# Altium schematic sheet Sheet3.SchDoc(Sheet3)
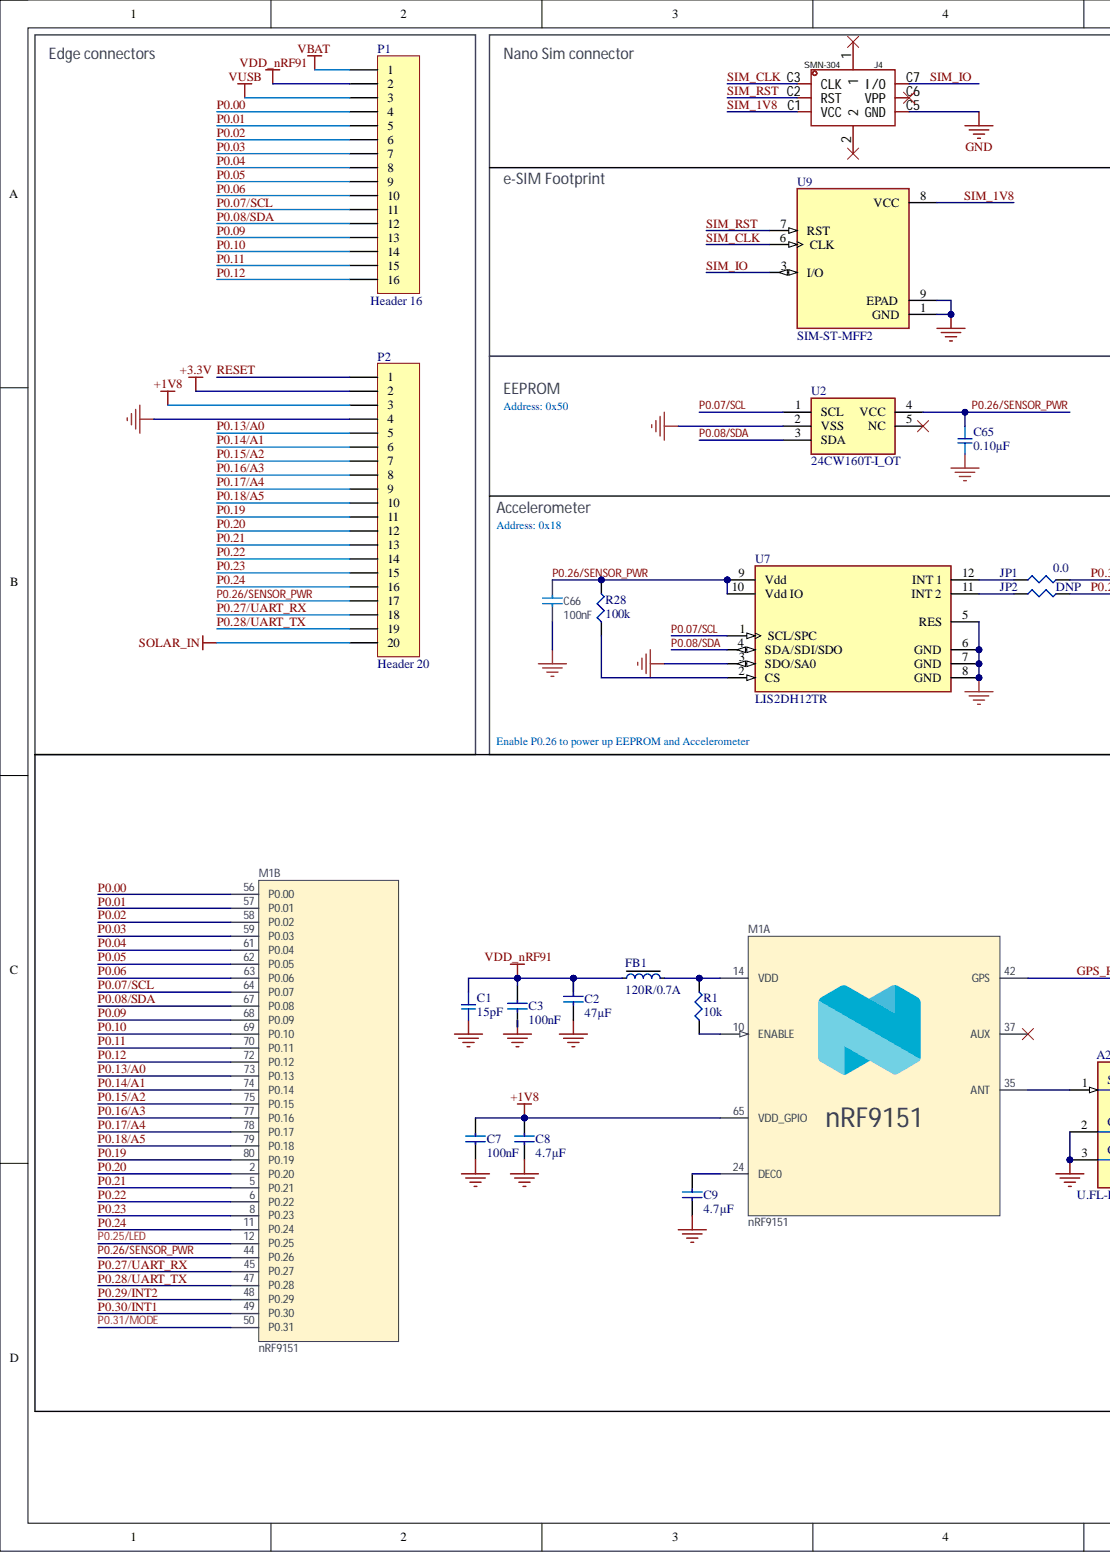
[diagram p0: Sheet3.SchDoc, left]
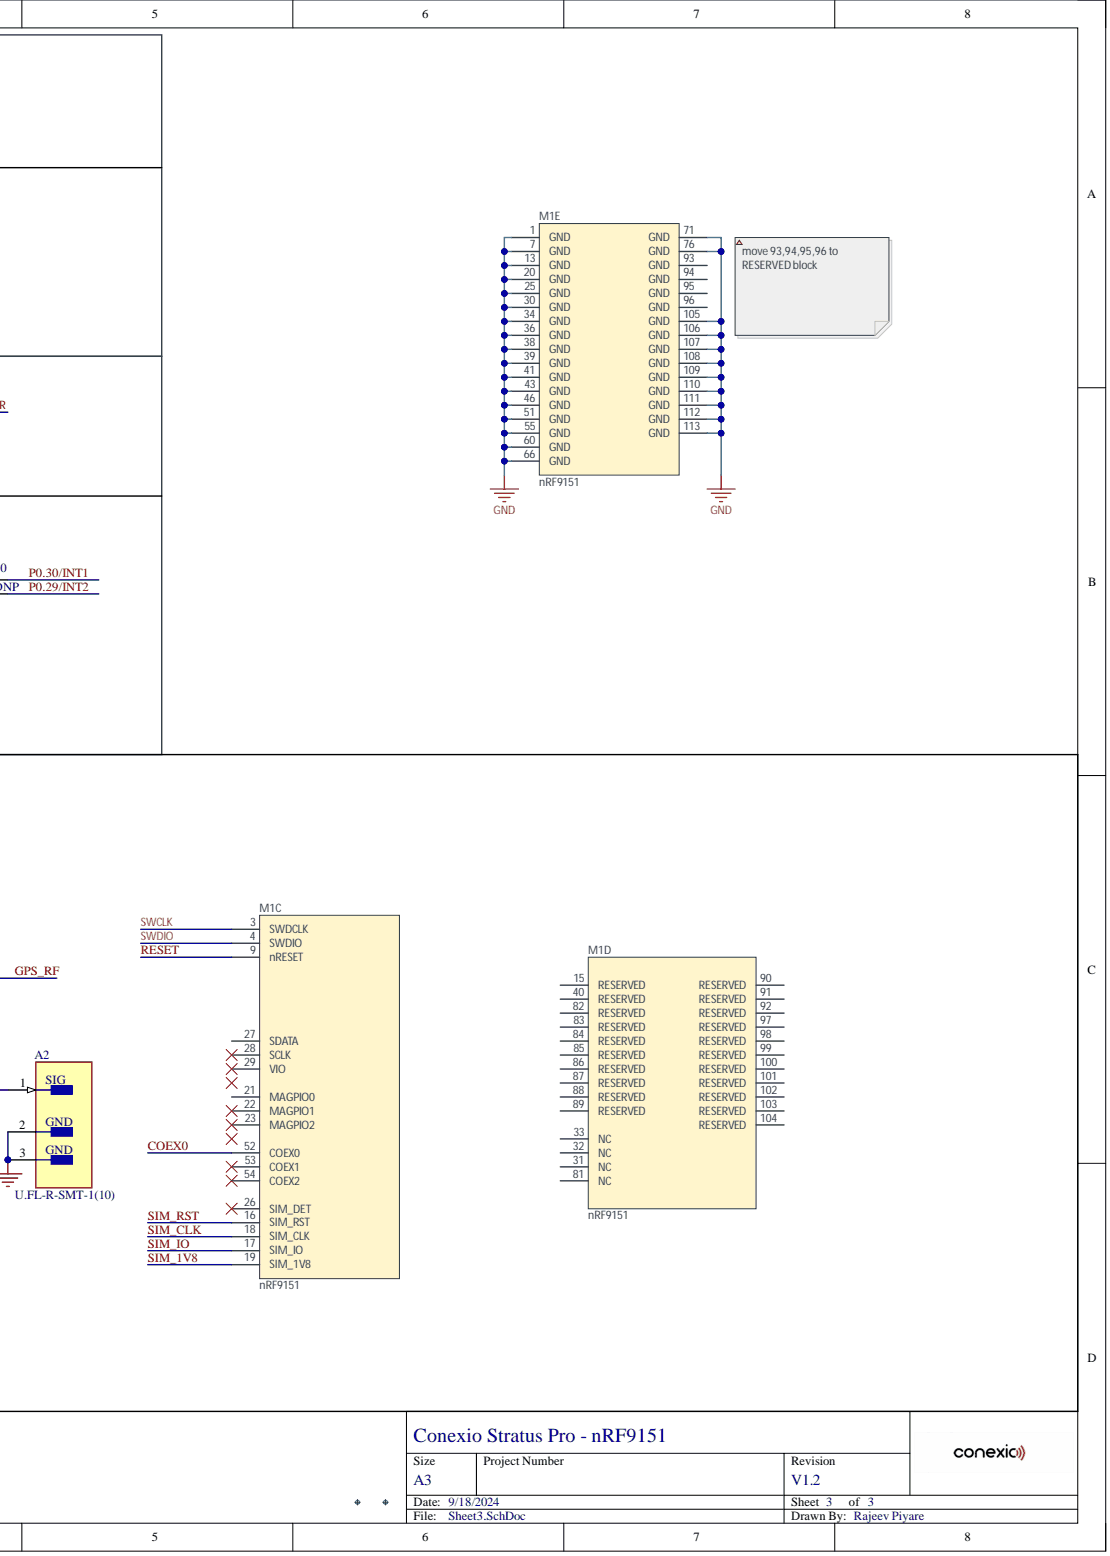
[diagram p1: Sheet3.SchDoc, right]

## Parts
A2  U.FL-R-SMT-1(10)
C1  15pF
C2  47µF
C3  100nF
C7  100nF
C8  4.7µF
C9  4.7µF
C65  0.10µF
C66  100nF
FB1  120R/0.7A
J4  SMN-304
JP1  0.0
JP2  DNP
M1  nRF9151  (parts A,B,C,D,E)
P1  Header 16
P2  Header 20
R1  10k
R28  100k
U2  24CW160T-I_OT
U7  LIS2DH12TR
U9  SIM-ST-MFF2

## Nets
+1V8: C7.1 C8.1 M1.65(VDD_GPIO) P2.3
+3.3V: P2.2
GND: A2.2 A2.3 C1.2 C2.2 C3.2 C7.2 C8.2 C9.2 C65.2 C66.1 J4.C5(GND) M1.1(GND) M1.7(GND) M1.13(GND) M1.20(GND) M1.25(GND) M1.30(GND) M1.34(GND) M1.36(GND) M1.38(GND) M1.39(GND) M1.41(GND) M1.43(GND) M1.46(GND) M1.51(GND) M1.55(GND) M1.60(GND) M1.66(GND) M1.71(GND) M1.76(GND) M1.105(GND) M1.106(GND) M1.107(GND) M1.108(GND) M1.109(GND) M1.110(GND) M1.111(GND) M1.112(GND) M1.113(GND) P2.4 U2.2(VSS) U7.3(SDO/SA0) U7.5(RES) U7.6(GND) U7.7(GND) U7.8(GND) U9.1(GND) U9.9(EPAD)
SOLAR_IN: P2.20
VBAT: P1.1
VDD_nRF91: C1.1 C2.1 C3.1 FB1.1 P1.2
VUSB: P1.3
COEX0: M1.52(COEX0)
GPS_RF: M1.42(GPS)
P0.00: M1.56(P0.00) P1.4
P0.01: M1.57(P0.01) P1.5
P0.02: M1.58(P0.02) P1.6
P0.03: M1.59(P0.03) P1.7
P0.04: M1.61(P0.04) P1.8
P0.05: M1.62(P0.05) P1.9
P0.06: M1.63(P0.06) P1.10
P0.07/SCL: M1.64(P0.07) P1.11 U2.1(SCL) U7.1(SCL/SPC)
P0.08/SDA: M1.67(P0.08) P1.12 U2.3(SDA) U7.4(SDA/SDI/SDO)
P0.09: M1.68(P0.09) P1.13
P0.10: M1.69(P0.10) P1.14
P0.11: M1.70(P0.11) P1.15
P0.12: M1.72(P0.12) P1.16
P0.13/A0: M1.73(P0.13) P2.5
P0.14/A1: M1.74(P0.14) P2.6
P0.15/A2: M1.75(P0.15) P2.7
P0.16/A3: M1.77(P0.16) P2.8
P0.17/A4: M1.78(P0.17) P2.9
P0.18/A5: M1.79(P0.18) P2.10
P0.19: M1.80(P0.19) P2.11
P0.20: M1.2(P0.20) P2.12
P0.21: M1.5(P0.21) P2.13
P0.22: M1.6(P0.22) P2.14
P0.23: M1.8(P0.23) P2.15
P0.24: M1.11(P0.24) P2.16
P0.25/LED: M1.12(P0.25)
P0.26/SENSOR_PWR: C65.1 C66.2 M1.44(P0.26) P2.17 R28.2 U2.4(VCC) U7.9(Vdd) U7.10(Vdd_IO)
P0.27/UART_RX: M1.45(P0.27) P2.18
P0.28/UART_TX: M1.47(P0.28) P2.19
P0.29/INT2: JP2.2 M1.48(P0.29)
P0.30/INT1: JP1.2 M1.49(P0.30)
P0.31/MODE: M1.50(P0.31)
RESET: M1.9(nRESET) P2.1
SIM_1V8: J4.C1(VCC) M1.19(SIM_1V8) U9.8(VCC)
SIM_CLK: J4.C3(CLK) M1.18(SIM_CLK) U9.6(CLK)
SIM_IO: J4.C7(I/O) M1.17(SIM_IO) U9.3(I/O)
SIM_RST: J4.C2(RST) M1.16(SIM_RST) U9.7(RST)
SWCLK: M1.3(SWDCLK)
SWDIO: M1.4(SWDIO)
NetA2_1: A2.1 M1.35(ANT)
NetC9_1: C9.1 M1.24(DEC0)
NetFB1_2: FB1.2 M1.14(VDD) R1.2
NetJP1_1: JP1.1 U7.12(INT_1)
NetJP2_1: JP2.1 U7.11(INT_2)
NetM1_10: M1.10(ENABLE) R1.1
NetR28_1: R28.1 U7.2(CS)

Not connected: J4 1 2 C6(VPP); M1 15(RESERVED) 21(MAGPIO0) 22(MAGPIO1) 23(MAGPIO2) 26(SIM_DET) 27(SDATA) 28(SCLK) 29(VIO) 31(NC) 32(NC) 33(NC) 37(AUX) 40(RESERVED) 53(COEX1) 54(COEX2) 81(NC) 82(RESERVED) 83(RESERVED) 84(RESERVED) 85(RESERVED) 86(RESERVED) 87(RESERVED) 88(RESERVED) 89(RESERVED) 90(RESERVED) 91(RESERVED) 92(RESERVED) 93(GND) 94(GND) 95(GND) 96(GND) 97(RESERVED) 98(RESERVED) 99(RESERVED) 100(RESERVED) 101(RESERVED) 102(RESERVED) 103(RESERVED) 104(RESERVED); U2 5(NC)

## Library data of the parts
A2 = CMP-2000-05152-3: CONN U.FL RCPT STR 50 OHM SMD; Body Material: Bronze; Body Plating: Silver; Connector Type: Coaxial; Dielectric Material: Polymer; Frequency: 6GHz; Gender: Male; Impedance: 50R; Insulation Resistance: 500MR; Component Kind: Standard; Contact Material: Brass; Contact Plating: Gold; Depth: 3.1mm; Fastening Type: Snap; Housing Color: Beige; Lead Free: Lead Free; Length: 3mm; Library Name: Altium Content Vault; Max Frequency: 6GHz; Max Operating Temperature: 90°C; Min Operating Temperature: -40°C; Mount: Surface Mount; Orientation: Straight; Package Quantity: 2500; Packaging: Cut Tape; Radiation Hardening: No; REACH SVHC: No SVHC; Series: U.FL; Termination: Solder; Weight: 0.001764oz; Wire/Cable Type: Coaxial
C1 = GCM1885C1H150JA16D: CAP CER 15PF 50V NP0 0603; Manufacturer: Murata Electronics North America; @ qty: 0; Minimum Quantity: 1; Temperature Coefficient: C0G, NP0; Tolerance: ±5%; Unit Price (USD): 0.1; Applications: Automotive; Component Kind: Standard; Datasheets: http://www.murata.com/~/media/webrenewal/support/library/catalog/products/capacitor/mlcc/c03e.ashx; Digi-Key Part Number: 490-4957-1-ND; Factory Stock: 0; Features: -; Height - Seated (Max): -; Image: //media.digikey.com/Renders/Murata%20Renders/0603%28GCM188%29.jpg; Lead Spacing: -; Lead Style: -; Library Name: capacitor_murata_0603.IntLib; Mounting Type: Surface Mount, MLCC; Operating Temperature: -55°C ~ 125°C; Package / Case: 0603 (1608 Metric); Packaging: Cut Tape (CT); Part Number: GCM1885C1H150JA16D; Part Status: Active; Quantity Available: 99209; Ratings: AEC-Q200; Series: GCM; Size / Dimension: 0.063" L x 0.031" W (1.60mm x 0.80mm); Thickness (Max): 0.035" (0.90mm); Value: 15pF; Voltage: 50V
C2 = C0805-47µ-10V: Capacitor, X5R, ±20%, 10V; Manufacturer: Murata; Manufacturer Part Number: GRM21BR61A476ME15; Part number IPS: 00000004759; Artikkelnr Noca: N.A.; Database Table Name: Parts$; Pad Count: 2; Component Class: Capacitors; Component Kind: Standard; ComponentLink1Description: Datasheet; ComponentLink1URL: https://search.murata.co.jp/Ceramy/image/img/A01X/G101/ENG/GRM21BR61A476ME15-01A.pdf; ComponentLink2Description: Supplier link; ComponentLink2URL: N.A.; Footprint Doc: 0805; HelpURL: https://www.murata.com/en-global/products/productdetail?partno=GRM21BR61A476ME15%23; LatestRevisionDate: 2020-10-23; Library Name: AltiumLib.DbLib; Note: 10V; Part Number: C0805-47µ-10V; PartId: 100200288; Published: 2020-08-14; Supplier 1: Digi-Key; Supplier 2: N.A.; Supplier Part Number 1: 490-9961-1-ND; Supplier Part Number 2: N.A.; Value: 47µF
C3 C7 = C0201-100n: Capacitor, X5R, ±10%; Part number IPS: 00000001248, 00000002505; Artikkelnr Noca: N.A.; Database Table Name: Parts$; Note: 16V; Pad Count: 2; Component Class: Capacitors; Component Kind: Standard; ComponentLink1Description: Datasheet; ComponentLink1URL: N.A.; ComponentLink2Description: Supplier link; ComponentLink2URL: N.A.; Footprint Doc: 0201; HelpURL: N.A.; LatestRevisionDate: 2017-11-09; Library Name: AltiumLib.DbLib; Manufacturer: N.A.; Manufacturer Part Number: N.A.; Part Number: C0201-100n; PartId: 100200078; Published: 2014-11-13; Supplier 1: N.A.; Supplier 2: N.A.; Supplier Part Number 1: N.A.; Supplier Part Number 2: N.A.; Value: 100nF
C8 C9 = C0603-4µ7: Capacitor, X5R, ±10%; Manufacturer: N.A.; Part number IPS: 00000007783; Artikkelnr Noca: 20069060; Database Table Name: Parts$; Note: 6.3V; Pad Count: 2; Component Class: Capacitors; Component Kind: Standard; ComponentLink1Description: Datasheet; ComponentLink1URL: N.A.; ComponentLink2Description: Supplier link; ComponentLink2URL: N.A.; Footprint Doc: 0603; HelpURL: N.A.; LatestRevisionDate: 2009-11-23; Library Name: AltiumLib.DbLib; Manufacturer Part Number: N.A.; Part Number: C0603-4µ7; PartId: 100200274; Published: 2009-05-08; Supplier 1: N.A.; Supplier 2: N.A.; Supplier Part Number 1: N.A.; Supplier Part Number 2: N.A.; Value: 4.7µF
C65 = GCJ188R71H104KA12D: CAP CER 0.1UF 50V X7R 0603; Manufacturer: Murata Electronics North America; @ qty: 0; Minimum Quantity: 1; Tolerance: ±10%; Unit Price (USD): 0.12; Applications: Automotive, Boardflex Sensitive; Component Kind: Standard; Datasheets: http://www.murata.com/~/media/webrenewal/support/library/catalog/products/capacitor/mlcc/c03e.ashx; Digi-Key Part Number: 490-5798-1-ND; Factory Stock: 0; Features: Soft Termination; Height - Seated (Max): -; Image: //media.digikey.com/Renders/Murata%20Renders/0603%28LQG18%29.jpg; Lead Spacing: -; Lead Style: -; Library Name: capacitor_murata_0603.IntLib; Mounting Type: Surface Mount, MLCC; Operating Temperature: -55°C ~ 125°C; Package / Case: 0603 (1608 Metric); Packaging: Cut Tape (CT); Part Number: GCJ188R71H104KA12D; Part Status: Active; Quantity Available: 163695; Ratings: AEC-Q200; Series: GCJ; Size / Dimension: 0.063" L x 0.031" W (1.60mm x 0.80mm); Temperature Coefficient: X7R; Thickness (Max): 0.035" (0.90mm); Value: 0.10µF; Voltage: 50V
C66 = C0201-100n-16V-X6S-MSASE063AC6104KFNA01: Capacitor, X6S, ±10%, 16V; Manufacturer: Taiyo Yuden; Part number NEMS: 100200544; Artikkelnr Noca: N.A.; Component Status: Active; Database Table Name: Parts$; Pad Count: 2; Component Class: Capacitors; Component Kind: Standard; ComponentLink1Description: Datasheet; ComponentLink1URL: https://www.yuden.co.jp/productdata/catalog/mlcc06_e.pdf; ComponentLink2Description: Supplier link; ComponentLink2URL: N.A.; Footprint Doc: 0201; HelpURL: https://ds.yuden.co.jp/TYCOMPAS/ut/detail?pn=MSASE063AC6104KFNA01&u=M; LatestRevisionDate: 2023-03-22; Library Name: AltiumLib.DbLib; Manufacturer Part Number: MSASE063AC6104KFNA01; Note: 16V; Part Number: C0201-100n-16V-X6S-MSASE063AC6104KFNA01; Part number IPS: N.A.; Part number Norautron: N.A.; PartId: 100200544; Published: 2023-03-22; Supplier 1: Digi-Key; Supplier 2: N.A.; Supplier Part Number 1: 587-6001-1-ND; Supplier Part Number 2: N.A.; Value: 100nF; Author: rubr
FB1 = FB0402-120R@100M-700mA: Ferrite Bead, 120 Ohm @ 100MHz, 700mA, 130 mOhm Max; Manufacturer: Murata; Manufacturer Part Number: BLM15AX121SN1; Part number IPS: 00000004487; Artikkelnr Noca: N.A.; Database Table Name: Parts$; Pad Count: 2; Component Class: Inductors; Component Kind: Standard; ComponentLink1Description: Datasheet; ComponentLink1URL: https://www.murata.com/en-us/products/productdata/8796740059166/ENFA0018.pdf; ComponentLink2Description: Supplier link; ComponentLink2URL: N.A.; Footprint Doc: 0402; HelpURL: https://www.murata.com/products/productdetail?partno=BLM15AX121SN1%23; LatestRevisionDate: 2020-04-28; Library Name: AltiumLib.DbLib; Note: N.A.; Part Number: FB0402-120R@100M-700mA; PartId: 100300100; Published: 2020-04-28; Supplier 1: Digi-Key; Supplier 2: N.A.; Supplier Part Number 1: 490-5439-1-ND; Supplier Part Number 2: N.A.; Value: 120R/0.7A
J4 = SMN-304: Manufacturer: XUNPU(讯普); Add into BOM: yes; Body Height (Max): 1.35mm; Card Connection Mode: Pluggable; Card Type: NanoSIM卡; Connector Type: Deck; Soldering Temperature(Max): 260℃; Component Kind: Standard; Contact Plating: Gold; Convert to PCB: yes; Datasheet: https://atta.szlcsc.com/upload/public/pdf/source/20210906/008C96EE0BDD3DBC40121ECB56D55849.pdf; Device: SMN-304; JLCPCB Part Class: Extended Part; LCSC Part Name: 拔插式 NanoSIM卡 卡座; Library Name: SMN-304.IntLib; Manufacturer Part: SMN-304; Operating Temperature Range: -40℃~+85℃; Supplier: LCSC; Supplier Footprint: SMD; Supplier Part: C266889; Symbol: SMN-304
JP1 = ERJ-3GEY0R00V: RES SMD 0.0OHM JUMPER 1/10W 0603; Manufacturer: Panasonic Electronic Components; @ qty: 1500; Factory Stock: 0; Minimum Quantity: 1; Power (Watts): 0.1W, 1/10W; Unit Price (USD): 0.00352; Component Kind: Standard; Composition: Thick Film; Datasheets: http://industrial.panasonic.com/www-cgi/jvcr13pz.cgi?E+PZ+3+AOA0001+ERJ3GEY0R00V+7+WW; Digi-Key Part Number: P0.0GCT-ND; Failure Rate: -; Features: Automotive AEC-Q200; Height - Seated (Max): 0.022" (0.55mm); Image: //media.digikey.com/Photos/Panasonic%20Photos/MFG_ERJ-P6W%20Series.jpg; Library Name: Panasonic_ERJ_0603.IntLib; Number of Terminations: 2; Operating Temperature: -55°C ~ 155°C; Package / Case: 0603 (1608 Metric); Packaging: Cut Tape (CT); Part Number: ERJ-3GEY0R00V; Part Status: Active; Quantity Available: 4813372; Series: ERJ; Size / Dimension: 0.063" L x 0.031" W (1.60mm x 0.80mm); Supplier Device Package: 0603; Temperature Coefficient: -; Tolerance: Jumper; Value: 0.0
JP2 = ERJ-3GEY0R00V: DO NOT PLACE RES SMD 0.0OHM JUMPER 1/10W 0603; Manufacturer: Panasonic Electronic Components; @ qty: 1500; Factory Stock: 0; Minimum Quantity: 1; Power (Watts): 0.1W, 1/10W; Unit Price (USD): 0.00352; Value: 0.0; Component Kind: Standard; Composition: Thick Film; Datasheets: http://industrial.panasonic.com/www-cgi/jvcr13pz.cgi?E+PZ+3+AOA0001+ERJ3GEY0R00V+7+WW; Digi-Key Part Number: P0.0GCT-ND; Failure Rate: -; Features: Automotive AEC-Q200; Height - Seated (Max): 0.022" (0.55mm); Image: //media.digikey.com/Photos/Panasonic%20Photos/MFG_ERJ-P6W%20Series.jpg; Library Name: Panasonic_ERJ_0603.IntLib; Number of Terminations: 2; Operating Temperature: -55°C ~ 155°C; Package / Case: 0603 (1608 Metric); Packaging: Cut Tape (CT); Part Number: ERJ-3GEY0R00V; Part Status: Active; Quantity Available: 4813372; Series: ERJ; Size / Dimension: 0.063" L x 0.031" W (1.60mm x 0.80mm); Supplier Device Package: 0603; Temperature Coefficient: -; Tolerance: Jumper
M1 = nRF9151: Low power System-in-Package with integrated LTE-M/NB-IoT modem and GPS; Manufacturer: Nordic Semiconductor; Artikkelnr Noca: N.A.; Component Status: Active; Database Table Name: Parts$; Pad Count: 113; Component Class: Nordic_Devices; Component Kind: Standard; ComponentLink1Description: Datasheet; ComponentLink1URL: N.A.; ComponentLink2Description: Supplier link; ComponentLink2URL: N.A.; Footprint Doc: LGA-113; HelpURL: N.A.; LatestRevisionDate: 2023-09-04; Library Name: AltiumLib.DbLib; Manufacturer Part Number: nRF9151; Note: N.A.; Part Number: nRF9151; Part number IPS: N.A.; Part number Norautron: N.A.; Published: 2023-09-04; Supplier 1: Nordic Semiconductor; Supplier 2: N.A.; Supplier Part Number 1: nRF9151; Supplier Part Number 2: N.A.; Value: nRF9151; Author: kod1
P1 = Header 16: Header, 16-Pin; Component Kind: Standard; LatestRevisionDate: 17-Jul-2002; LatestRevisionNote: Re-released for DXP Platform.; Library Name: Miscellaneous Connectors.IntLib; Publisher: Altium Limited
P2 = Header 20: Header, 20-Pin; Component Kind: Standard; LatestRevisionDate: 17-Jul-2002; LatestRevisionNote: Re-released for DXP Platform.; Library Name: Miscellaneous Connectors.IntLib; Publisher: Altium Limited
R1 = ERJ-3EKF1002V: RES SMD 10K OHM 1% 1/10W 0603; Manufacturer: Panasonic Electronic Components; @ qty: 1500; Factory Stock: 0; Minimum Quantity: 1; Power (Watts): 0.1W, 1/10W; Temperature Coefficient: ±100ppm/°C; Unit Price (USD): 0.00379; Component Kind: Standard; Composition: Thick Film; Datasheets: http://industrial.panasonic.com/www-cgi/jvcr13pz.cgi?E+PZ+3+AOA0002+ERJ3EKF1002V+7+WW; Digi-Key Part Number: P10.0KHCT-ND; Failure Rate: -; Features: Automotive AEC-Q200; Height - Seated (Max): 0.022" (0.55mm); Image: //media.digikey.com/Photos/Panasonic%20Photos/MFG_ERJ-P6W%20Series.jpg; Library Name: Panasonic_ERJ_0603.IntLib; Number of Terminations: 2; Operating Temperature: -55°C ~ 155°C; Package / Case: 0603 (1608 Metric); Packaging: Cut Tape (CT); Part Number: ERJ-3EKF1002V; Part Status: Active; Quantity Available: 6703141; Series: ERJ; Size / Dimension: 0.063" L x 0.031" W (1.60mm x 0.80mm); Supplier Device Package: 0603; Tolerance: ±1%; Value: 10k
R28 = ERJ-3EKF1003V: RES SMD 100K OHM 1% 1/10W 0603; Manufacturer: Panasonic Electronic Components; @ qty: 1500; Factory Stock: 0; Minimum Quantity: 1; Power (Watts): 0.1W, 1/10W; Temperature Coefficient: ±100ppm/°C; Unit Price (USD): 0.00379; Component Kind: Standard; Composition: Thick Film; Datasheets: http://industrial.panasonic.com/www-cgi/jvcr13pz.cgi?E+PZ+3+AOA0002+ERJ3EKF1003V+7+WW; Digi-Key Part Number: P100KHCT-ND; Failure Rate: -; Features: Automotive AEC-Q200; Height - Seated (Max): 0.022" (0.55mm); Image: //media.digikey.com/Photos/Panasonic%20Photos/MFG_ERJ-P6W%20Series.jpg; Library Name: Panasonic_ERJ_0603.IntLib; Number of Terminations: 2; Operating Temperature: -55°C ~ 155°C; Package / Case: 0603 (1608 Metric); Packaging: Cut Tape (CT); Part Number: ERJ-3EKF1003V; Part Status: Active; Quantity Available: 2179804; Series: ERJ; Size / Dimension: 0.063" L x 0.031" W (1.60mm x 0.80mm); Supplier Device Package: 0603; Tolerance: ±1%; Value: 100k
U2 = 24CW160T-I_OT: Integrated Circuit; Manufacturer_Name: Microchip; Manufacturer_Part_Number: 24CW160T-I/OT; Arrow Part Number: 24CW160T-I/OT; Arrow Price/Stock: https://www.arrow.com/en/products/24cw160t-iot/microchip-technology?region=nac; Component Kind: Standard; Datasheet Link: http://ww1.microchip.com/downloads/en/DeviceDoc/24CW16X-24CW32X-24CW64X-24CW128X-Data-Sheet-20005772B.pdf; Height: 1.45mm; Mouser Part Number: 579-24CW160T-I/OT; Mouser Price/Stock: https://www.mouser.co.uk/ProductDetail/Microchip-Technology/24CW160T-I-OT?qs=F5EMLAvA7IAMss1CRRO1Kw%3D%3D
U7 = CMP-2000-05369-2: ACCEL 2-16G I2C/SPI 12LGA; Axis: X, Y, Z; Bandwidth: 672Hz; Interface: I2C; Max Supply Voltage: 3.6V; Min Supply Voltage: 1.71V; Output Type: SPI; Case/Package: LGA; Component Kind: Standard; Contact Plating: Gold; Height: 1mm; Lead Free: Lead Free; Length: 2.15mm; Library Name: Altium Content Vault; Max Operating Temperature: 85°C; Min Operating Temperature: -40°C; Mount: Surface Mount; Number of Pins: 12; Packaging: Cut Tape; Radiation Hardening: No; REACH SVHC: No SVHC; Width: 2.15mm
U9 = SIM-ST-MFF2: GLOBAL IOT EMBEDDED SIM CHIP; MF: {'manufacturer': u'Hologram, Inc.'}; Availability: Unavailable; Component Kind: Standard; MP: SIM-ST-MFF2; Package: None; Price: None
